# Altium schematic sheet 3_POWER SWITCH AND LDO.SchDoc
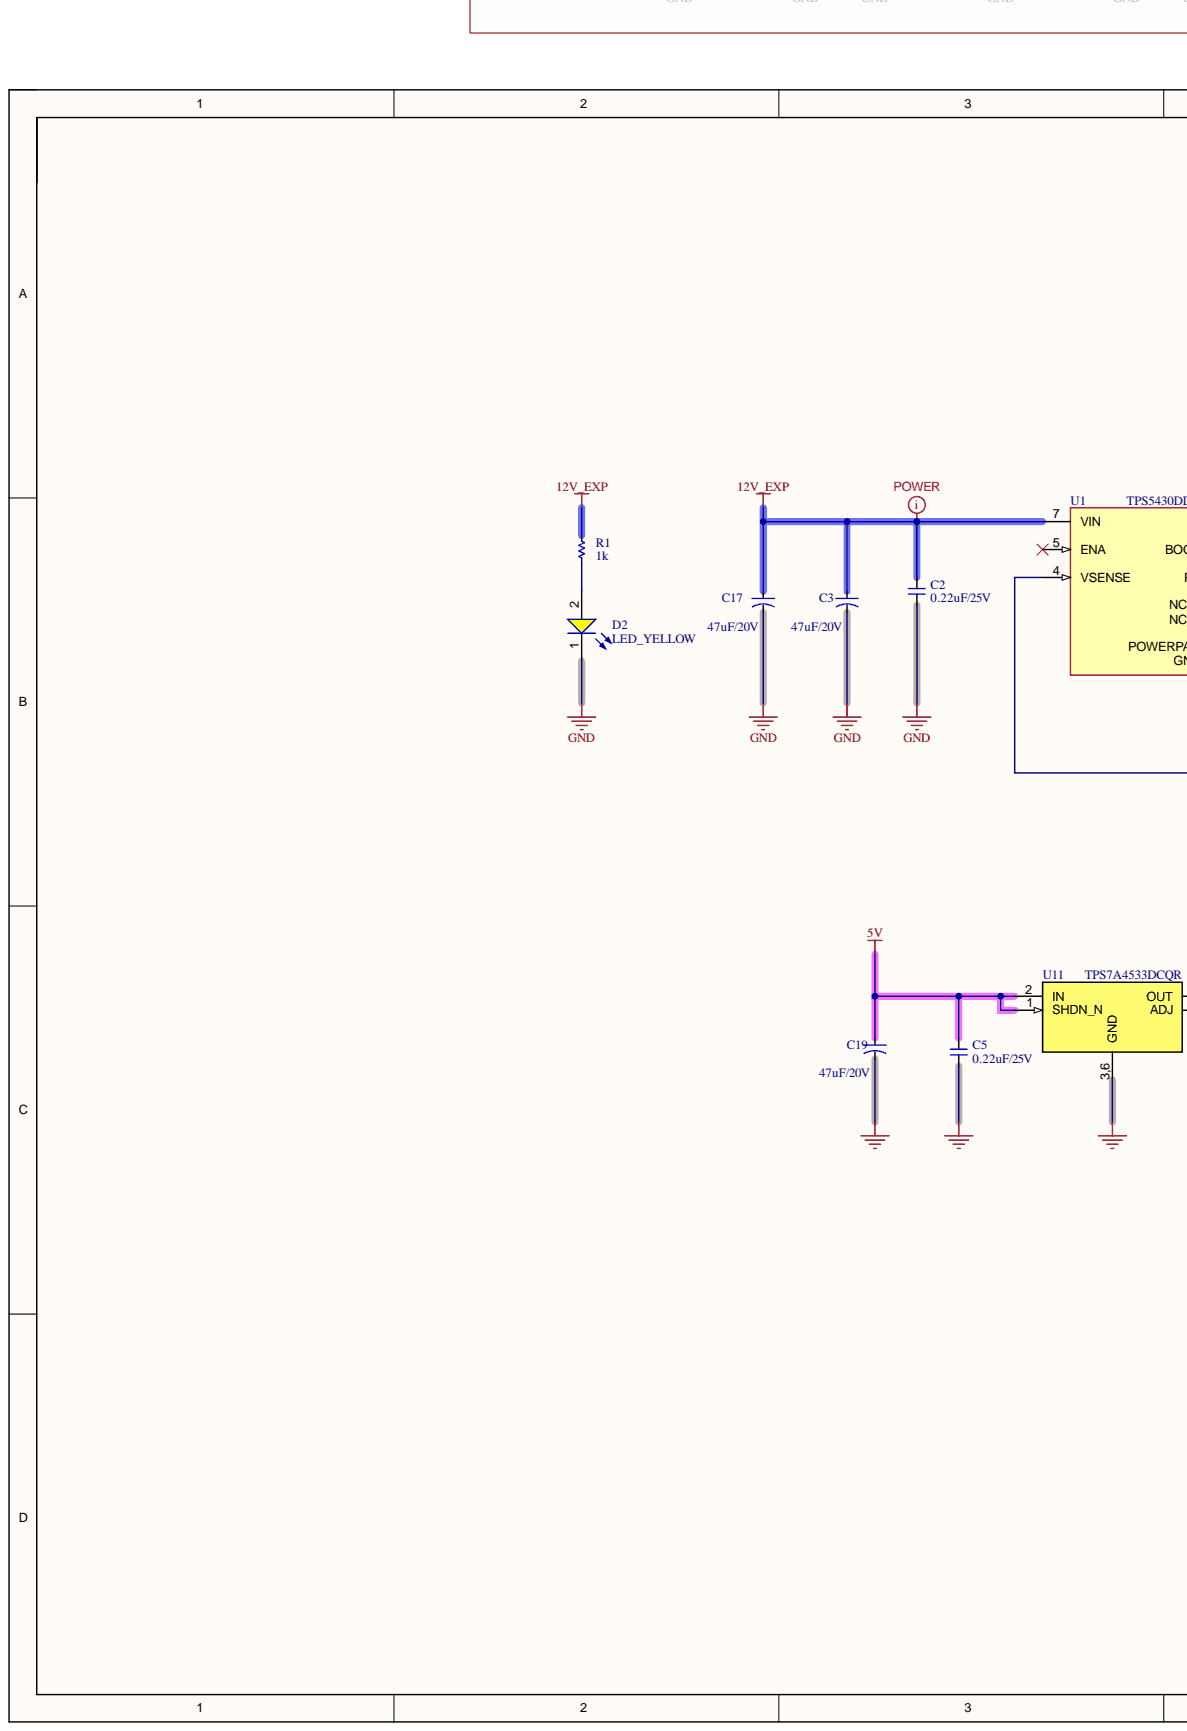
[diagram p0: 3_POWER SWITCH AND LDO.SchDoc, left]
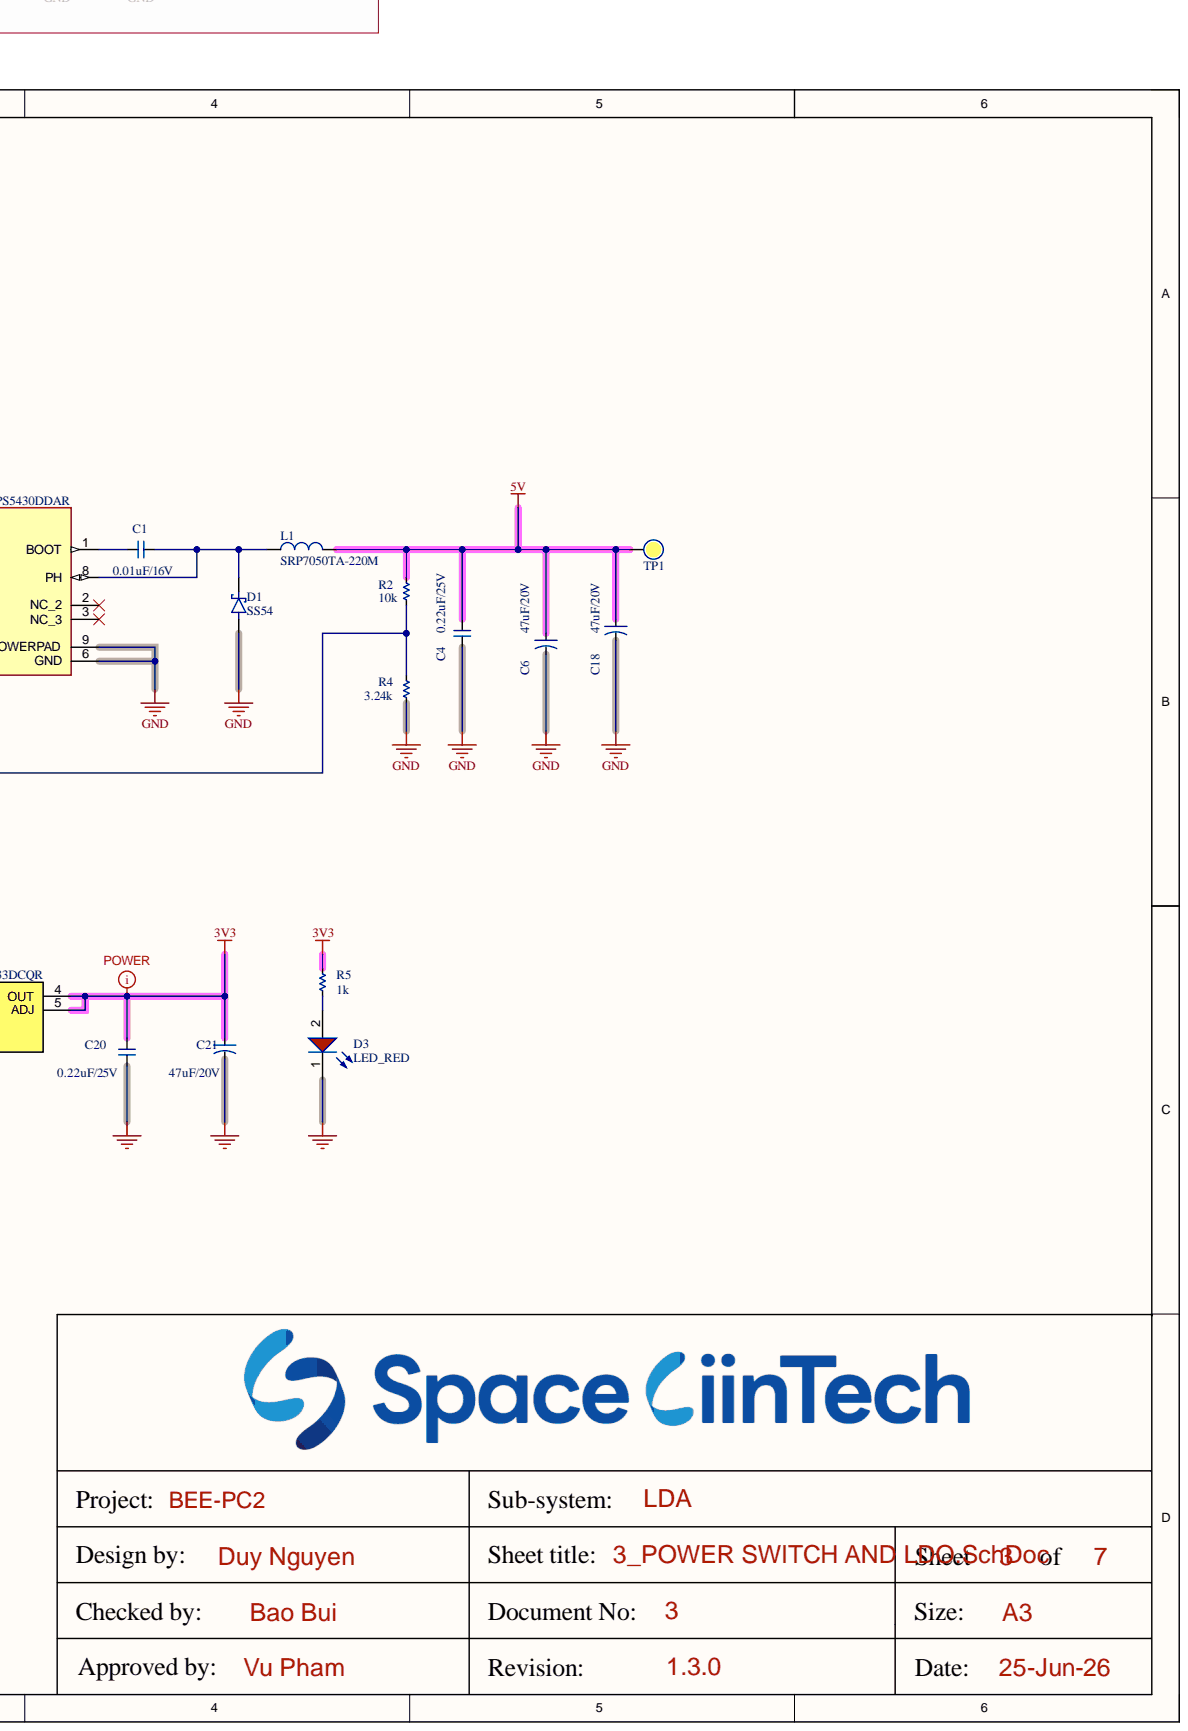
[diagram p1: 3_POWER SWITCH AND LDO.SchDoc, right]

## Parts
C1  0.01uF/16V  [CAP_C_1608X80]
C2  0.22uF/25V  [CAP_C_1608X80]
C3  47uF/20V  [CAP TANT 2312]
C4  0.22uF/25V  [CAP_C_1608X80]
C5  0.22uF/25V  [CAP_C_1608X80]
C6  47uF/20V  [CAP TANT 2312]
C17  47uF/20V  [CAP TANT 2312]
C18  47uF/20V  [CAP TANT 2312]
C19  47uF/20V  [CAP TANT 2312]
C20  0.22uF/25V  [CAP_C_1608X80]
C21  47uF/20V  [CAP TANT 2312]
D1  SS54  [DO-214AC_SMA]
D2  LED_YELLOW  [LED_0603]
D3  LED_RED  [LED_0603]
L1  SRP7050TA-220M  [PSM6050]
R1  1k  [RES_C_1005X40]
R2  10k  [RES_C_1005X40]
R4  3.24k  [RES_C_1005X40]
R5  1k  [RES_C_1005X40]
TP1  (no value)  [Testpoint_80]  (no BOM)
U1  TPS5430DDAR  [CONV_TPS5430DDAR]
U11  TPS7A4533DCQR  [SOT223-6]

## Nets
3V3: C20.2 C21.1 R5.2 U11.4(OUT) U11.5(ADJ)
5V: C4.2 C5.2 C6.1 C18.1 C19.1 L1.2 R2.2 TP1.1 U11.1(SHDN_N) U11.2(IN)
12V_EXP: C2.2 C3.1 C17.1 R1.2 U1.7(VIN)
GND: C2.1 C3.2 C4.1 C5.1 C6.2 C17.2 C18.2 C19.2 C20.1 C21.2 D1.2 D2.1 D3.1 R4.1 U1.6(GND) U1.9(POWERPAD) U11.3,6(GND)
NetC1_1: C1.1 D1.1 L1.1 U1.8(PH)
NetC1_2: C1.2 U1.1(BOOT)
NetD2_2: D2.2 R1.1
NetD3_2: D3.2 R5.1
NetR2_1: R2.1 R4.2 U1.4(VSENSE)

Not connected: U1 2(NC_2) 3(NC_3) 5(ENA)

## Library data of the parts
C1 C2 C4 C5 C20 = _CAP_C_2: CAP; Component Kind: Standard; Library Name: LABLIB.SchLib
C3 C6 C17-C19 C21 = CN-ALU-SMD: TAJC476K020RNJ; Component Kind: Standard; LatestRevisionDate: 17-Jul-2002; LatestRevisionNote: Re-released for DXP Platform.; PackageReference: POLAR0.8; Published: 8-Jun-2000; Library Name: LASER_LIB_SCHE.SchLib; Publisher: Altium Limited
D1 = DIODE SCHOTTKY: Component Kind: Standard; Description: DIODE SCHOTTKY; Library Name: LABLIB.SchLib
L1 = SRP7050TA-220M: FIXED IND 22UH 2.5A 170 MOHM SMD; Component Kind: Standard; Library Name: LABLIB.SchLib
R1 R2 R4 R5 = _RES_B_2: RES; Component Kind: Standard; Library Name: LABLIB.SchLib
TP1 = Test Point: Solder: NF; Description: Test Point; Library Name: LASER_LIB_SCHE.SchLib
U1 = TPS5430DDAR: 5.5V to 36V Input, 3A, 500kHz Step-Down Converter; MF: Texas Instruments; Availability: In Stock; Check_prices: https://www.snapeda.com/parts/TPS5430DDAR/Texas+Instruments/view-part/?ref=eda; Component Kind: Standard; MP: TPS5430DDAR; Package: HSOIC-8 Texas Instruments; Price: None; Purchase-URL: https://pricing.snapeda.com/search/part/TPS5430DDAR/?ref=eda; SnapEDA_Link: https://www.snapeda.com/parts/TPS5430DDAR/Texas+Instruments/view-part/?ref=snap; Library Name: LASER_LIB_SCHE.SchLib
D2 = LED_YELLOW: Component Kind: Standard; Library Name: LASER_LIB_SCHE.SchLib
D3 = LED_RED: Component Kind: Standard; Library Name: LASER_LIB_SCHE.SchLib
U11 = TPS7A4533DCQR: Component Kind: Standard; Description: TPS7A4533DCQR; Library Name: LASER_LIB_SCHE.SchLib
